# Altium schematic sheet PAGE03 FPGA IO.SchDoc
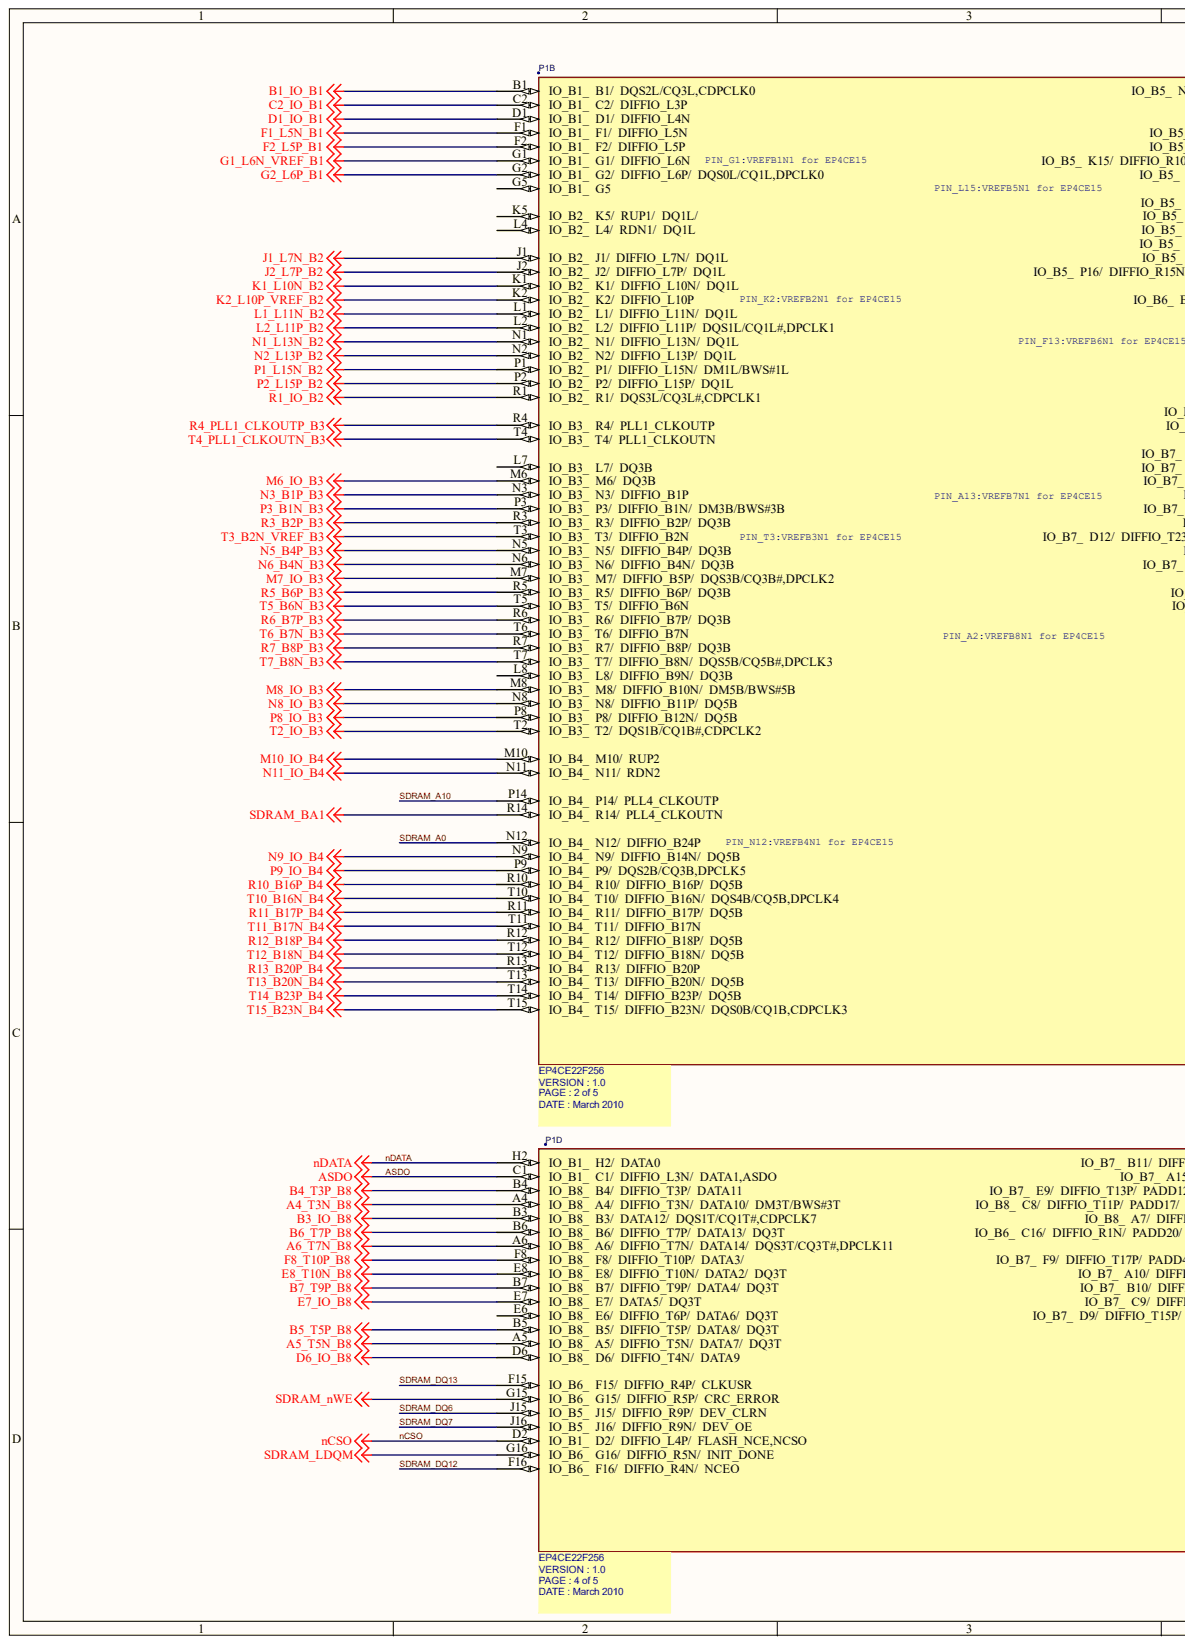
[diagram p0: PAGE03 FPGA IO.SchDoc, left]
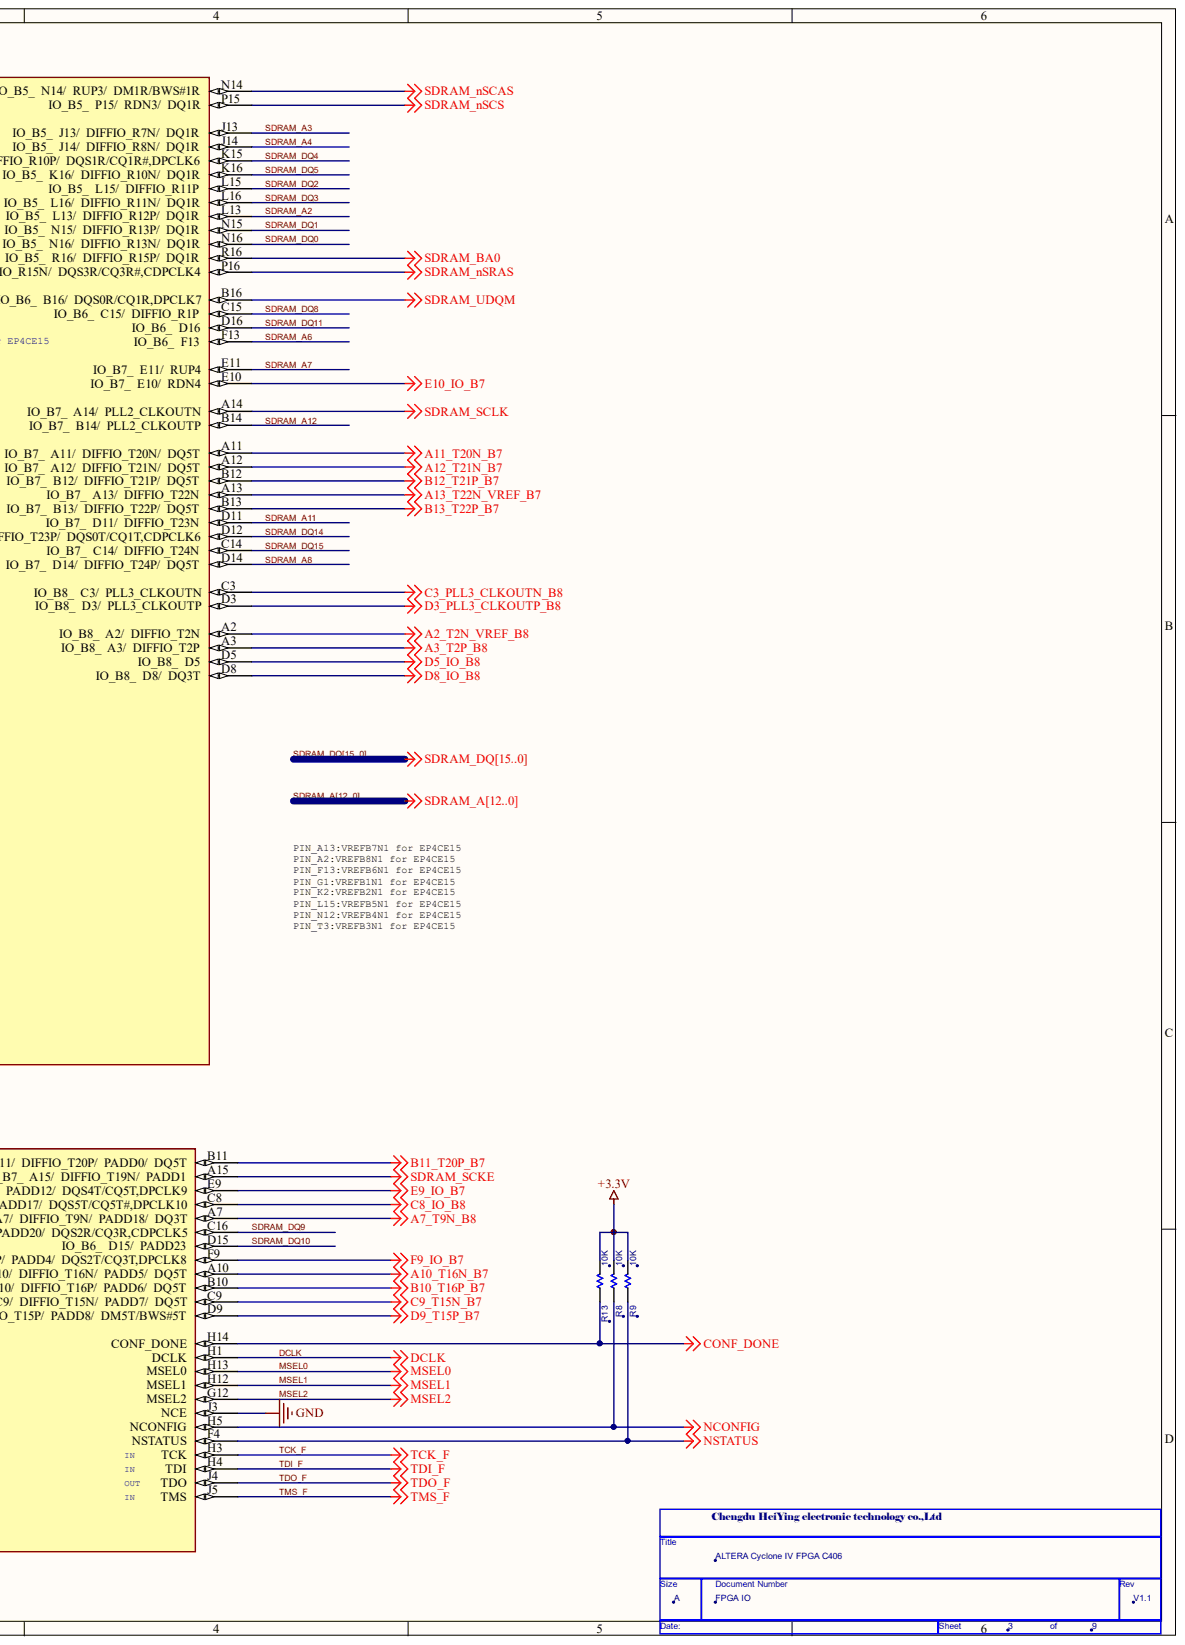
[diagram p1: PAGE03 FPGA IO.SchDoc, right]

## Parts
P1  EP4CE22F256  [fbga256_opt1]  (parts B,D)
R8  10K  [R0402]
R9  10K  [R0402]
R13  10K  [R0402]

## Nets
+3.3V: R8.2 R9.2 R13.2
A2_T2N_VREF_B8: P1.A2(DIFFIO_T2N)
A3_T2P_B8: P1.A3(DIFFIO_T2P)
A4_T3N_B8: P1.A4(IO_B8_)
A5_T5N_B8: P1.A5(IO_B8_)
A6_T7N_B8: P1.A6(IO_B8_)
A7_T9N_B8: P1.A7(DQ3T)
A10_T16N_B7: P1.A10(DQ5T)
A11_T20N_B7: P1.A11(DQ5T)
A12_T21N_B7: P1.A12(DQ5T)
A13_T22N_VREF_B7: P1.A13(DIFFIO_T22N)
B1_IO_B1: P1.B1(IO_B1_)
B3_IO_B8: P1.B3(IO_B8_)
B4_T3P_B8: P1.B4(IO_B8_)
B5_T5P_B8: P1.B5(IO_B8_)
B6_T7P_B8: P1.B6(IO_B8_)
B7_T9P_B8: P1.B7(IO_B8_)
B10_T16P_B7: P1.B10(DQ5T)
B11_T20P_B7: P1.B11(DQ5T)
B12_T21P_B7: P1.B12(DQ5T)
B13_T22P_B7: P1.B13(DQ5T)
C2_IO_B1: P1.C2(IO_B1_)
C3_PLL3_CLKOUTN_B8: P1.C3(PLL3_CLKOUTN)
C8_IO_B8: P1.C8(DQS5T/CQ5T#,DPCLK10)
C9_T15N_B7: P1.C9(DQ5T)
CONF_DONE: P1.H14(CONF_DONE) R13.1
D1_IO_B1: P1.D1(IO_B1_)
D3_PLL3_CLKOUTP_B8: P1.D3(PLL3_CLKOUTP)
D5_IO_B8: P1.D5
D6_IO_B8: P1.D6(IO_B8_)
D8_IO_B8: P1.D8(DQ3T)
D9_T15P_B7: P1.D9(DM5T/BWS#5T)
E7_IO_B8: P1.E7(IO_B8_)
E8_T10N_B8: P1.E8(IO_B8_)
E9_IO_B7: P1.E9(DQS4T/CQ5T,DPCLK9)
E10_IO_B7: P1.E10(RDN4)
F1_L5N_B1: P1.F1(IO_B1_)
F2_L5P_B1: P1.F2(IO_B1_)
F8_T10P_B8: P1.F8(IO_B8_)
F9_IO_B7: P1.F9(DQS2T/CQ3T,DPCLK8)
G1_L6N_VREF_B1: P1.G1(IO_B1_)
G2_L6P_B1: P1.G2(IO_B1_)
GND: P1.J3(NCE)
J1_L7N_B2: P1.J1(IO_B2_)
J2_L7P_B2: P1.J2(IO_B2_)
K1_L10N_B2: P1.K1(IO_B2_)
K2_L10P_VREF_B2: P1.K2(IO_B2_)
L1_L11N_B2: P1.L1(IO_B2_)
L2_L11P_B2: P1.L2(IO_B2_)
M6_IO_B3: P1.M6(IO_B3_)
M7_IO_B3: P1.M7(IO_B3_)
M8_IO_B3: P1.M8(IO_B3_)
M10_IO_B4: P1.M10(IO_B4_)
N1_L13N_B2: P1.N1(IO_B2_)
N2_L13P_B2: P1.N2(IO_B2_)
N3_B1P_B3: P1.N3(IO_B3_)
N5_B4P_B3: P1.N5(IO_B3_)
N6_B4N_B3: P1.N6(IO_B3_)
N8_IO_B3: P1.N8(IO_B3_)
N9_IO_B4: P1.N9(IO_B4_)
N11_IO_B4: P1.N11(IO_B4_)
NCONFIG: P1.H5(NCONFIG) R8.1
NSTATUS: P1.F4(NSTATUS) R9.1
P1_L15N_B2: P1.P1(IO_B2_)
P2_L15P_B2: P1.P2(IO_B2_)
P3_B1N_B3: P1.P3(IO_B3_)
P8_IO_B3: P1.P8(IO_B3_)
P9_IO_B4: P1.P9(IO_B4_)
R1_IO_B2: P1.R1(IO_B2_)
R3_B2P_B3: P1.R3(IO_B3_)
R4_PLL1_CLKOUTP_B3: P1.R4(IO_B3_)
R5_B6P_B3: P1.R5(IO_B3_)
R6_B7P_B3: P1.R6(IO_B3_)
R7_B8P_B3: P1.R7(IO_B3_)
R10_B16P_B4: P1.R10(IO_B4_)
R11_B17P_B4: P1.R11(IO_B4_)
R12_B18P_B4: P1.R12(IO_B4_)
R13_B20P_B4: P1.R13(IO_B4_)
SDRAM_BA0: P1.R16(DQ1R)
SDRAM_BA1: P1.R14(IO_B4_)
SDRAM_LDQM: P1.G16(IO_B6_)
SDRAM_NSCAS: P1.N14(DM1R/BWS#1R)
SDRAM_NSCS: P1.P15(DQ1R)
SDRAM_NSRAS: P1.P16(DQS3R/CQ3R#,CDPCLK4)
SDRAM_NWE: P1.G15(IO_B6_)
SDRAM_SCKE: P1.A15(PADD1)
SDRAM_SCLK: P1.A14(PLL2_CLKOUTN)
SDRAM_UDQM: P1.B16(DQS0R/CQ1R,DPCLK7)
T2_IO_B3: P1.T2(IO_B3_)
T3_B2N_VREF_B3: P1.T3(IO_B3_)
T4_PLL1_CLKOUTN_B3: P1.T4(IO_B3_)
T5_B6N_B3: P1.T5(IO_B3_)
T6_B7N_B3: P1.T6(IO_B3_)
T7_B8N_B3: P1.T7(IO_B3_)
T10_B16N_B4: P1.T10(IO_B4_)
T11_B17N_B4: P1.T11(IO_B4_)
T12_B18N_B4: P1.T12(IO_B4_)
T13_B20N_B4: P1.T13(IO_B4_)
T14_B23P_B4: P1.T14(IO_B4_)
T15_B23N_B4: P1.T15(IO_B4_)
ASDO: P1.C1(IO_B1_)
DCLK: P1.H1(DCLK)
MSEL0: P1.H13(MSEL0)
MSEL1: P1.H12(MSEL1)
MSEL2: P1.G12(MSEL2)
NCSO: P1.D2(IO_B1_)
NDATA: P1.H2(IO_B1_)
SDRAM_A0: P1.N12(IO_B4_)  [also labelled SDRAM_A]
SDRAM_A2: P1.L13(DQ1R)  [also labelled SDRAM_A]
SDRAM_A3: P1.J13(DQ1R)  [also labelled SDRAM_A]
SDRAM_A4: P1.J14(DQ1R)  [also labelled SDRAM_A]
SDRAM_A6: P1.F13  [also labelled SDRAM_A]
SDRAM_A7: P1.E11(RUP4)  [also labelled SDRAM_A]
SDRAM_A8: P1.D14(DQ5T)  [also labelled SDRAM_A]
SDRAM_A10: P1.P14(IO_B4_)  [also labelled SDRAM_A]
SDRAM_A11: P1.D11(DIFFIO_T23N)  [also labelled SDRAM_A]
SDRAM_A12: P1.B14(PLL2_CLKOUTP)  [also labelled SDRAM_A]
SDRAM_DQ0: P1.N16(DQ1R)  [also labelled SDRAM_DQ]
SDRAM_DQ1: P1.N15(DQ1R)  [also labelled SDRAM_DQ]
SDRAM_DQ2: P1.L15(DIFFIO_R11P)  [also labelled SDRAM_DQ]
SDRAM_DQ3: P1.L16(DQ1R)  [also labelled SDRAM_DQ]
SDRAM_DQ4: P1.K15(DQS1R/CQ1R#,DPCLK6)  [also labelled SDRAM_DQ]
SDRAM_DQ5: P1.K16(DQ1R)  [also labelled SDRAM_DQ]
SDRAM_DQ6: P1.J15(IO_B5_)  [also labelled SDRAM_DQ]
SDRAM_DQ7: P1.J16(IO_B5_)  [also labelled SDRAM_DQ]
SDRAM_DQ8: P1.C15(DIFFIO_R1P)  [also labelled SDRAM_DQ]
SDRAM_DQ9: P1.C16(DQS2R/CQ3R,CDPCLK5)  [also labelled SDRAM_DQ]
SDRAM_DQ10: P1.D15(PADD23)  [also labelled SDRAM_DQ]
SDRAM_DQ11: P1.D16  [also labelled SDRAM_DQ]
SDRAM_DQ12: P1.F16(IO_B6_)  [also labelled SDRAM_DQ]
SDRAM_DQ13: P1.F15(IO_B6_)  [also labelled SDRAM_DQ]
SDRAM_DQ14: P1.D12(DQS0T/CQ1T,CDPCLK6)  [also labelled SDRAM_DQ]
SDRAM_DQ15: P1.C14(DIFFIO_T24N)  [also labelled SDRAM_DQ]
TCK_F: P1.H3(TCK)
TDI_F: P1.H4(TDI)
TDO_F: P1.J4(TDO)
TMS_F: P1.J5(TMS)
SDRAM_A1:  [also labelled SDRAM_A]
SDRAM_A5:  [also labelled SDRAM_A]
SDRAM_A9:  [also labelled SDRAM_A]

Not connected: P1 E6(IO_B8_) G5(IO_B1_) K5(IO_B2_) L4(IO_B2_) L7(IO_B3_) L8(IO_B3_)

## Library data of the parts
P1 = EP4CE22F256: SPLIT_INST: TRUE; SWAP_INFO: (S1+S2+S3+S4+S5); Component Kind: Standard
R8 R9 R13 = SMALL R1: Component Kind: Standard
